# Altium schematic sheet top_level.SchDoc
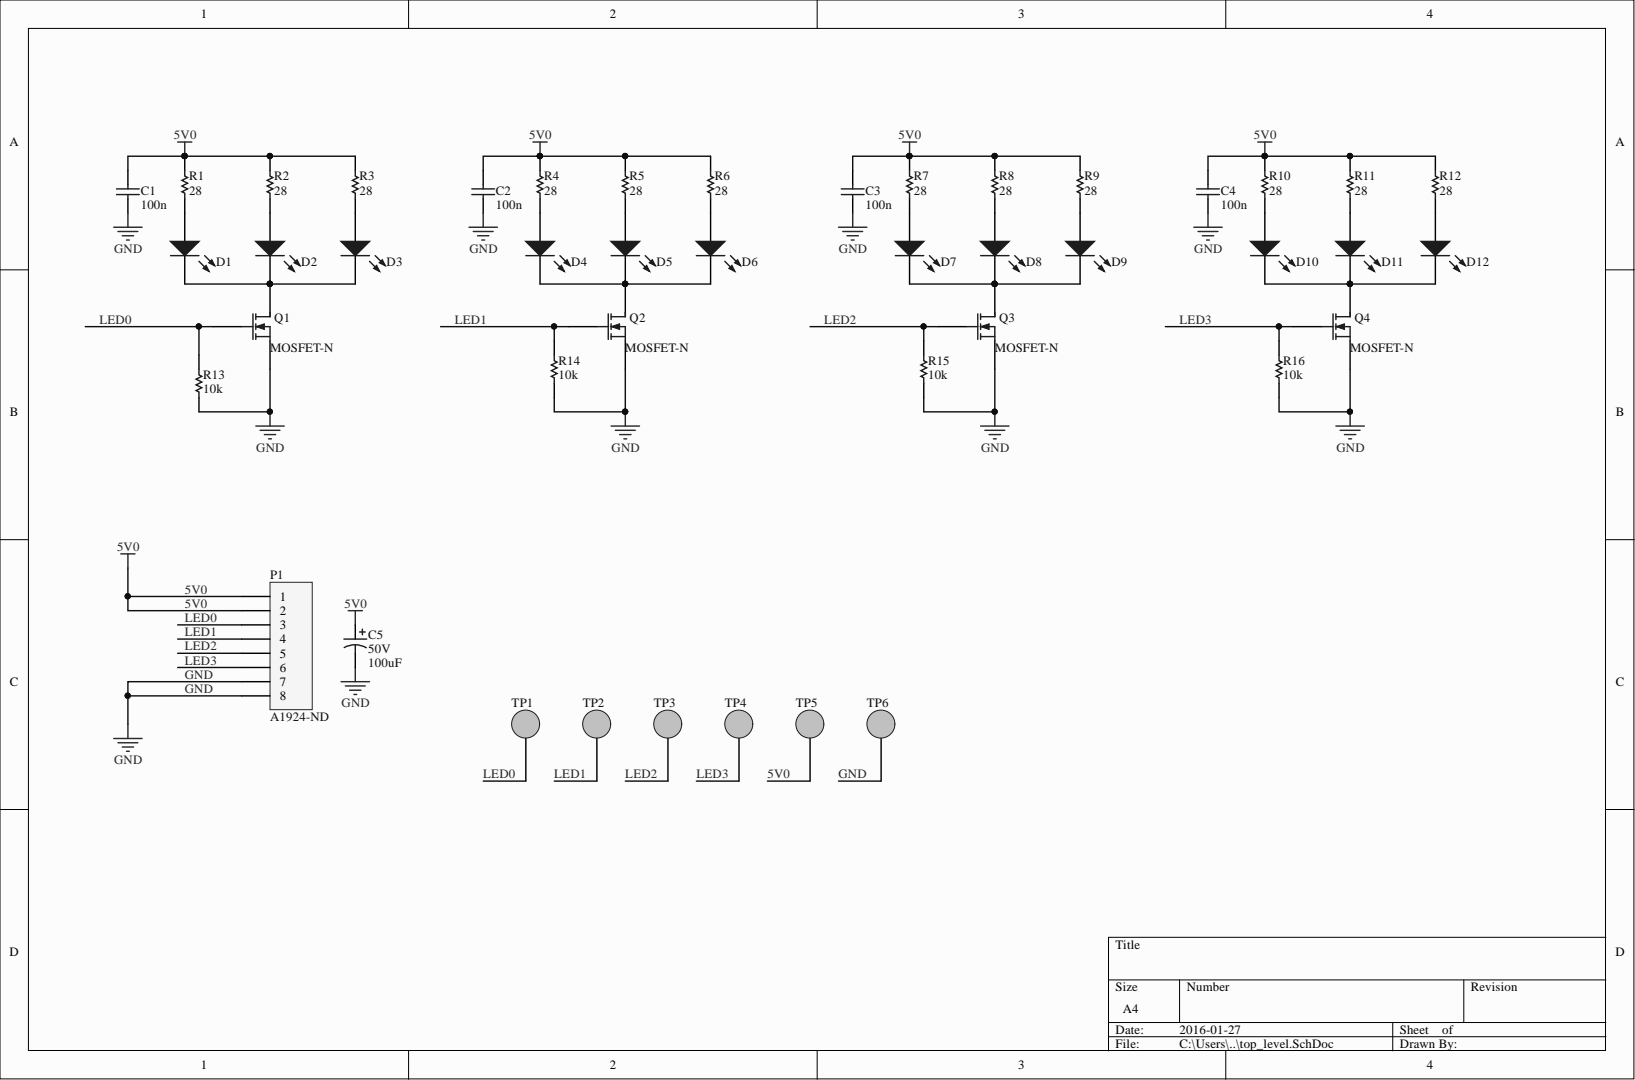
[diagram p0: top_level.SchDoc]

## Parts
C1  value 100n  [CS-0603]  (hidden comment: CS - CAPACITOR)
C2  value 100n  [CS-0603]  (hidden comment: CS - CAPACITOR)
C3  value 100n  [CS-0603]  (hidden comment: CS - CAPACITOR)
C4  value 100n  [CS-0603]  (hidden comment: CS - CAPACITOR)
C5  value 100uF  VOLTAGE: 50V  [CT-CAP-ALUM-8X14]  (hidden comment: CT - CAPACITOR ELECTROLYTIC)
D1  LED - NORMAL LED  [LED-1]  (value not drawn)
D2  LED - NORMAL LED  [LED-1]  (value not drawn)
D3  LED - NORMAL LED  [LED-1]  (value not drawn)
D4  LED - NORMAL LED  [LED-1]  (value not drawn)
D5  LED - NORMAL LED  [LED-1]  (value not drawn)
D6  LED - NORMAL LED  [LED-1]  (value not drawn)
D7  LED - NORMAL LED  [LED-1]  (value not drawn)
D8  LED - NORMAL LED  [LED-1]  (value not drawn)
D9  LED - NORMAL LED  [LED-1]  (value not drawn)
D10  LED - NORMAL LED  [LED-1]  (value not drawn)
D11  LED - NORMAL LED  [LED-1]  (value not drawn)
D12  LED - NORMAL LED  [LED-1]  (value not drawn)
P1  Supplier Part Number 1: A1924-ND  [CON-HEADER-1X8]  (hidden comment: CON - HEADER 1X8)
Q1  MOSFET-N  [TO-220AB]
Q2  MOSFET-N  [TO-220AB]
Q3  MOSFET-N  [TO-220AB]
Q4  MOSFET-N  [TO-220AB]
R1  value 28  [RS-1210]  (hidden comment: RS - RESISTOR)
R2  value 28  [RS-1210]  (hidden comment: RS - RESISTOR)
R3  value 28  [RS-1210]  (hidden comment: RS - RESISTOR)
R4  value 28  [RS-1210]  (hidden comment: RS - RESISTOR)
R5  value 28  [RS-1210]  (hidden comment: RS - RESISTOR)
R6  value 28  [RS-1210]  (hidden comment: RS - RESISTOR)
R7  value 28  [RS-1210]  (hidden comment: RS - RESISTOR)
R8  value 28  [RS-1210]  (hidden comment: RS - RESISTOR)
R9  value 28  [RS-1210]  (hidden comment: RS - RESISTOR)
R10  value 28  [RS-1210]  (hidden comment: RS - RESISTOR)
R11  value 28  [RS-1210]  (hidden comment: RS - RESISTOR)
R12  value 28  [RS-1210]  (hidden comment: RS - RESISTOR)
R13  value 10k  [RS-0603]  (hidden comment: RS - RESISTOR)
R14  value 10k  [RS-0603]  (hidden comment: RS - RESISTOR)
R15  value 10k  [RS-0603]  (hidden comment: RS - RESISTOR)
R16  value 10k  [RS-0603]  (hidden comment: RS - RESISTOR)
TP1  5003K  [TP - VERTICAL]  (value not drawn)
TP2  5003K  [TP - VERTICAL]  (value not drawn)
TP3  5003K  [TP - VERTICAL]  (value not drawn)
TP4  5003K  [TP - VERTICAL]  (value not drawn)
TP5  5003K  [TP - VERTICAL]  (value not drawn)
TP6  5003K  [TP - VERTICAL]  (value not drawn)

## Nets
5V0: C1.1 C2.1 C3.1 C4.1 C5.1 P1.1 P1.2 R1.2 R2.2 R3.2 R4.2 R5.2 R6.2 R7.2 R8.2 R9.2 R10.2 R11.2 R12.2 TP5.1
GND: C1.2 C2.2 C3.2 C4.2 C5.2 P1.7 P1.8 Q1.3 Q2.3 Q3.3 Q4.3 R13.1 R14.1 R15.1 R16.1 TP6.1
LED0: P1.3 Q1.2 R13.2 TP1.1
LED1: P1.4 Q2.2 R14.2 TP2.1
LED2: P1.5 Q3.2 R15.2 TP3.1
LED3: P1.6 Q4.2 R16.2 TP4.1
NetD1_A: D1.A R1.1
NetD1_K: D1.K D2.K D3.K Q1.1
NetD2_A: D2.A R2.1
NetD3_A: D3.A R3.1
NetD4_A: D4.A R4.1
NetD4_K: D4.K D5.K D6.K Q2.1
NetD5_A: D5.A R5.1
NetD6_A: D6.A R6.1
NetD7_A: D7.A R7.1
NetD7_K: D7.K D8.K D9.K Q3.1
NetD8_A: D8.A R8.1
NetD9_A: D9.A R9.1
NetD10_A: D10.A R10.1
NetD10_K: D10.K D11.K D12.K Q4.1
NetD11_A: D11.A R11.1
NetD12_A: D12.A R12.1

## Library data of the parts
C1-C4 = CS - CAPACITOR: TOLERANCE: 10%; VOLTAGE: 16V; Component Kind: Standard; Library Name: CHINOOK_LIBRARY.IntLib
C5 = CT - CAPACITOR ELECTROLYTIC: TOLERANCE: 10%; Component Kind: Standard; Library Name: CHINOOK_LIBRARY.IntLib
P1 = CON - HEADER 1X8: 100mil header 1 row 6 pins; Component Kind: Standard; Supplier 1: Digi-Key; Library Name: CHINOOK_LIBRARY.IntLib
Q1-Q4 = MOSFET-N: N-Channel MOSFET; Component Kind: Standard; LatestRevisionDate: 17-Jul-2002; LatestRevisionNote: Re-released for DXP Platform.; Library Name: Miscellaneous Devices.IntLib; PackageDescription: TO, Flat Index; 3 In-Line, Axial Leads; Body Dia. 4.6mm; Lead Dia. 0.5 mm (max); PackageReference: E3; PackageVersion: 1995/1996; Published: 8-Jun-2000; Simulation: NMOS; Supplier name: Digikey; Supplier Part Number: IRFI530NPBF-ND; Publisher: Altium Limited
R1-R16 = RS - RESISTOR: POWER: RES POWER; TOLERANCE: RES TOL; Component Kind: Standard; Library Name: CHINOOK_LIBRARY.IntLib
  R1-R12: Supplier 1: Digikey; Supplier Part Number 1: P28.0AACT-ND
TP1-TP6 = TP - Test point vertical: Component Kind: Standard; Supplier 1: Newark; Supplier Part Number 1: 62W5140; Library Name: CHINOOK_LIBRARY.IntLib
D1-D12 = LED - NORMAL LED: Component Kind: Standard; Supplier 1: Digikey; Supplier Part Number 1: VLCS5830-ND; Library Name: CHINOOK_LIBRARY.IntLib
